# Altium schematic sheet Chip_LORA.SchDoc(Chip_LORA)
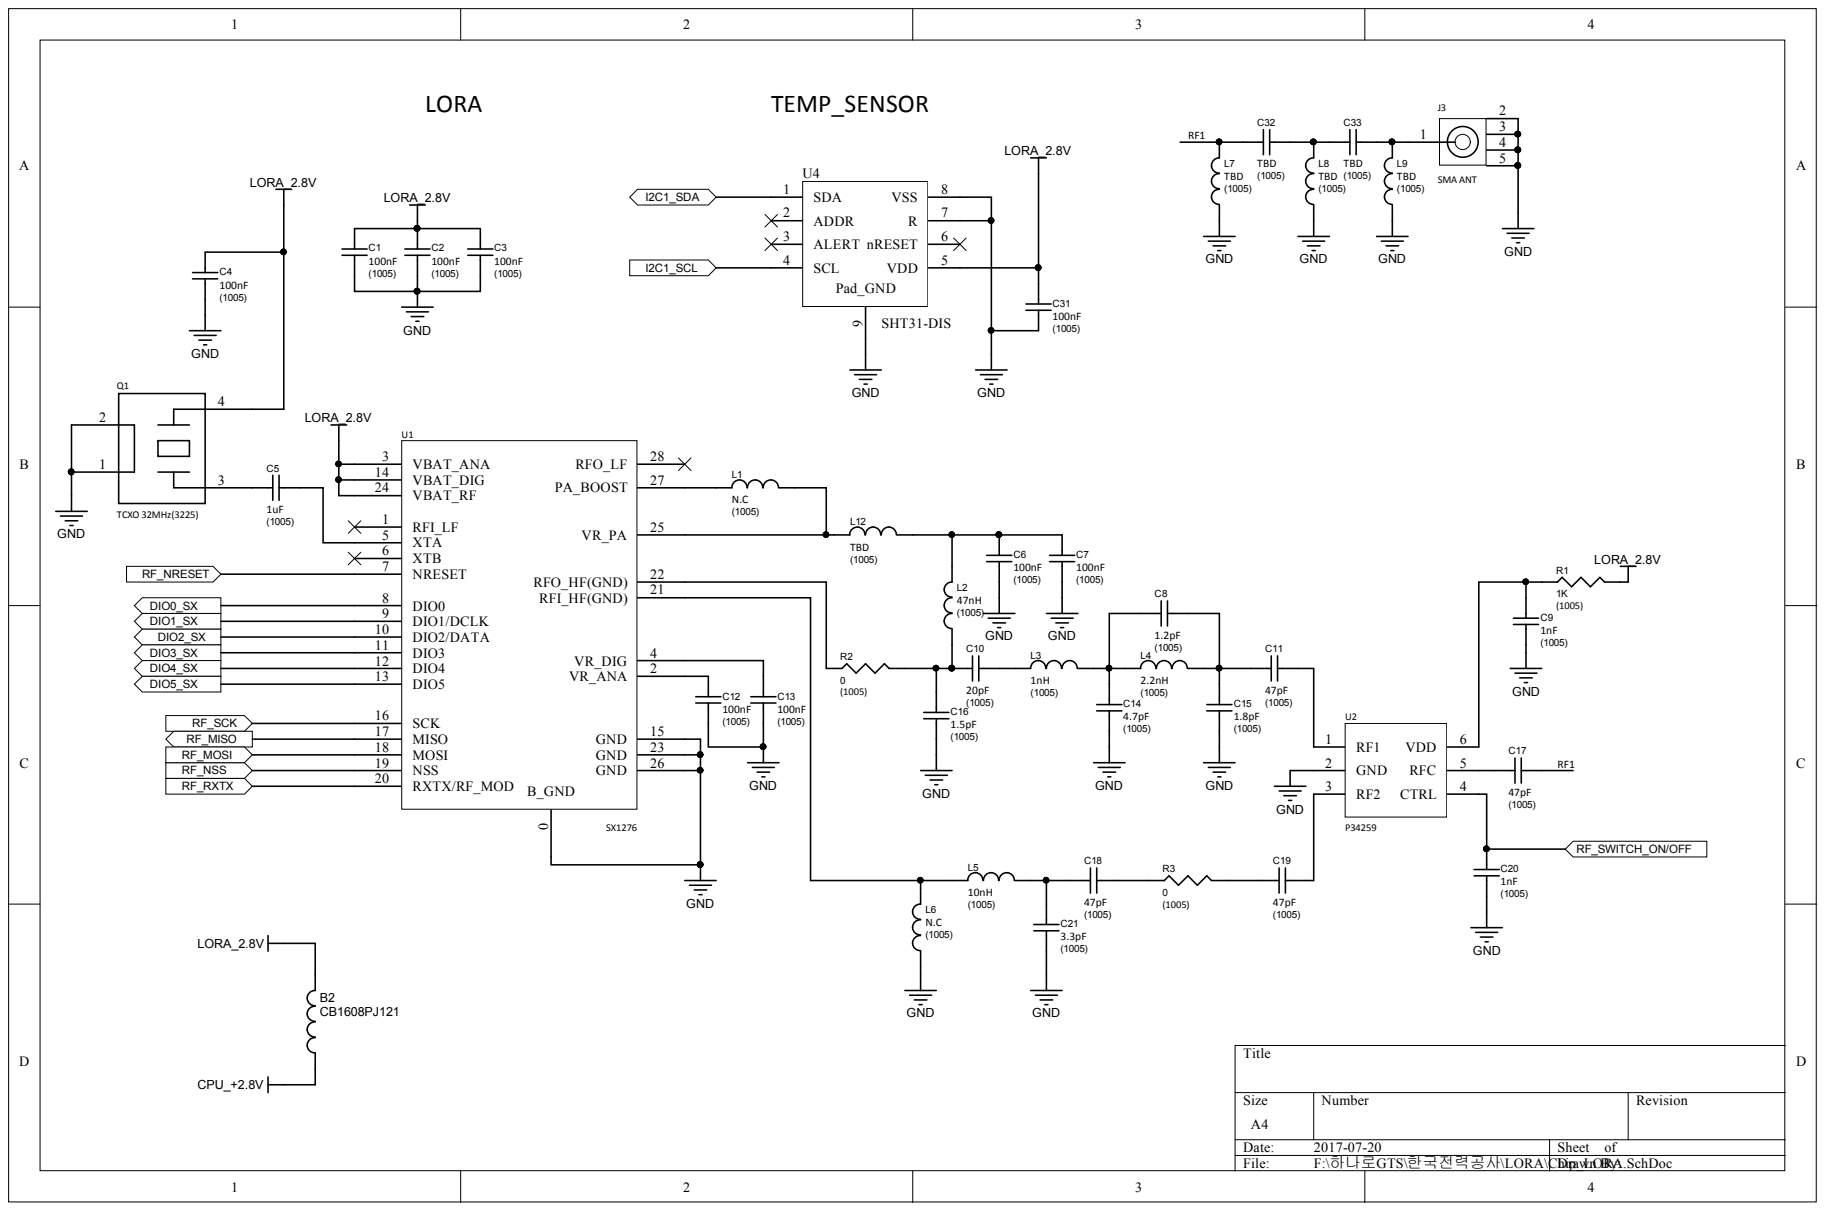
[diagram p0: Chip_LORA.SchDoc]

## Parts
B2  CB1608PJ121  [INDC1608L]
C1  100nF  value (1005)  [C1005]
C2  100nF  value (1005)  [C1005]
C3  100nF  value (1005)  [C1005]
C4  100nF  value (1005)  [C1005]
C5  1uF  value (1005)  [C1005]
C6  100nF  value (1005)  [C1005]
C7  100nF  value (1005)  [C1005]
C8  1.2pF  value (1005)  [C1005]
C9  1nF  value (1005)  [C1005]
C10  20pF  value (1005)  [C1005]
C11  47pF  value (1005)  [C1005]
C12  100nF  value (1005)  [C1005]
C13  100nF  value (1005)  [C1005]
C14  4.7pF  value (1005)  [C1005]
C15  1.8pF  value (1005)  [C1005]
C16  1.5pF  value (1005)  [C1005]
C17  47pF  value (1005)  [C1005]
C18  47pF  value (1005)  [C1005]
C19  47pF  value (1005)  [C1005]
C20  1nF  value (1005)  [C1005]
C21  3.3pF  value (1005)  [C1005]
C31  100nF  value (1005)  [C1005]
C32  TBD  value (1005)  [C1005]
C33  TBD  value (1005)  [C1005]
J3  SMA ANT  [SMA]
L1  N.C  value (1005)  [L1005]
L2  47nH  value (1005)  [L1005]
L3  1nH  value (1005)  [L1005]
L4  2.2nH  value (1005)  [L1005]
L5  10nH  value (1005)  [L1005]
L6  N.C  value (1005)  [L1005]
L7  TBD  value (1005)  [L1005]
L8  TBD  value (1005)  [L1005]
L9  TBD  value (1005)  [L1005]
L12  TBD  value (1005)  [L1005]
Q1  TCXO 32MHz(3225)  [TC323]
R1  1K  value (1005)  [R1005]
R2  0  value (1005)  [R1005]
R3  0  value (1005)  [R1005]
U1  SX1276  [SX1276]
U2  P34259  [PE4259DS]
U4  SHT31-DIS  [SHT31-DIS]

## Ports
DIO0_SX, DIO1_SX, DIO2_SX, DIO3_SX, DIO4_SX, DIO5_SX, I2C1_SCL, I2C1_SDA, RF_MISO, RF_MOSI, RF_NRESET, RF_NSS, RF_RXTX, RF_SCK, RF_SWITCH_ON/OFF

## Nets
CPU_+2.8V: B2.1
GND: C1.1 C2.1 C3.1 C4.1 C6.1 C7.1 C9.2 C12.1 C13.1 C14.2 C15.1 C16.1 C20.2 C21.2 C31.1 J3.2 J3.3 J3.4 J3.5 L6.1 L7.1 L8.1 L9.1 Q1.1 Q1.2 U1.0 U1.15(GND) U1.23(GND) U1.26(GND) U2.2(GND) U4.7(R) U4.8(VSS) U4.9
LORA_2.8V: B2.2 C1.2 C2.2 C3.2 C4.2 C31.2 Q1.4 R1.2 U1.3(VBAT_ANA) U1.14(VBAT_DIG) U1.24(VBAT_RF) U4.5(VDD)
DIO0_SX: U1.8(DIO0) ; port DIO0_SX
DIO1_SX: U1.9(DIO1/DCLK) ; port DIO1_SX
DIO2_SX: U1.10(DIO2/DATA) ; port DIO2_SX
DIO3_SX: U1.11(DIO3) ; port DIO3_SX
DIO4_SX: U1.12(DIO4) ; port DIO4_SX
DIO5_SX: U1.13(DIO5) ; port DIO5_SX
I2C1_SCL: U4.4(SCL) ; port I2C1_SCL
I2C1_SDA: U4.1(SDA) ; port I2C1_SDA
RF1: C17.1 C32.2 L7.2
RF_MISO: U1.17(MISO) ; port RF_MISO
RF_MOSI: U1.18(MOSI) ; port RF_MOSI
RF_NRESET: U1.7(NRESET) ; port RF_NRESET
RF_NSS: U1.19(NSS) ; port RF_NSS
RF_RXTX: U1.20(RXTX/RF_MOD) ; port RF_RXTX
RF_SCK: U1.16(SCK) ; port RF_SCK
RF_SWITCH_ON/OFF: C20.1 U2.4(CTRL) ; port RF_SWITCH_ON/OFF
NetC5_1: C5.1 Q1.3
NetC5_2: C5.2 U1.5(XTA)
NetC6_2: C6.2 C7.2 L2.2 L12.2
NetC8_1: C8.1 C14.1 L3.2 L4.1
NetC8_2: C8.2 C11.2 C15.2 L4.2
NetC9_1: C9.1 R1.1 U2.6(VDD)
NetC10_1: C10.1 L3.1
NetC10_2: C10.2 C16.2 L2.1 R2.2
NetC11_1: C11.1 U2.1(RF1)
NetC12_2: C12.2 U1.2(VR_ANA)
NetC13_2: C13.2 U1.4(VR_DIG)
NetC17_2: C17.2 U2.5(RFC)
NetC18_1: C18.1 R3.1
NetC18_2: C18.2 C21.1 L5.2
NetC19_1: C19.1 U2.3(RF2)
NetC19_2: C19.2 R3.2
NetC32_1: C32.1 C33.2 L8.2
NetC33_1: C33.1 J3.1 L9.2
NetL1_1: L1.1 U1.27(PA_BOOST)
NetL1_2: L1.2 L12.1 U1.25(VR_PA)
NetL5_1: L5.1 L6.2 U1.21(RFI_HF(GND))
NetR2_1: R2.1 U1.22(RFO_HF(GND))

Not connected: U1 1(RFI_LF) 6(XTB) 28(RFO_LF); U4 2(ADDR) 3(ALERT) 6(nRESET)

## Library data of the parts
B2 = BEAD: Code_IEC: 1005; Code_JEITA: 0402; Component Kind: Standard; Description: bead; LatestRevisionDate: 29-May-2009; LatestRevisionNote: IPC-7351 Footprint Added.; Library Name: S_BOARD.SchLib; PackageDescription: Chip Inductor; PackageReference: 0402-A; Published: 8-Jun-2000; Signal Integrity: Inductor; Simulation: INDUCTOR; Value: CB1608PJ121; Publisher: Altium Limited
C1-C7 C9 C11-C17 C19-C21 C31-C33 = C1005: Capacitor (Semiconductor SIM Model); Component Kind: Standard; LatestRevisionDate: 29-May-2009; LatestRevisionNote: IPC-7351 Footprint Added.; PackageDescription: Chip Capacitor; PackageReference: C1206; Published: 8-Jun-2000; Signal Integrity: Cap Semi; Simulation: CAP; Publisher: Altium Limited
  C1-C7 C9 C11-C17 C19-C21: Library Name: S_BOARD.SchLib
  C32 C33: Library Name: LORA.SCHLIB
  C31: Library Name: L_BackBoard.SchLib
C8 C10 C18 = C1005: Capacitor (Semiconductor SIM Model); Value: (1005); Component Kind: Standard; LatestRevisionDate: 29-May-2009; LatestRevisionNote: IPC-7351 Footprint Added.; Library Name: S_BOARD.SchLib; PackageDescription: Chip Capacitor; PackageReference: C1206; Published: 8-Jun-2000; Signal Integrity: Cap Semi; Simulation: CAP; Publisher: Altium Limited
J3 = INT ANT: SMA; Component Kind: Standard; Library Name: LORA.SCHLIB
L1-L9 L12 = L1005: Code_IEC: 1005; Code_JEITA: 0402; Component Kind: Standard; LatestRevisionDate: 29-May-2009; LatestRevisionNote: IPC-7351 Footprint Added.; PackageDescription: Chip Inductor; PackageReference: 0402-A; Published: 8-Jun-2000; Signal Integrity: Inductor; Simulation: INDUCTOR; Publisher: Altium Limited
  L1-L6: Library Name: S_BOARD.SchLib
  L7-L9 L12: Library Name: LORA.SCHLIB
Q1 = TCXO 32MHz: TCXO 32MHz 3.2mmX2.5mm; Component Kind: Standard; Library Name: LORA.SCHLIB
R1 = R1005: Value: (1005); Component Kind: Standard; Library Name: S_BOARD.SchLib
R2 R3 = R1005: Component Kind: Standard; Library Name: S_BOARD.SchLib
U1 = SX1276: 137 MHz to 1020 MHz Low Power Long Range Transceiver; Component Kind: Standard; Library Name: LORA.SCHLIB
U2 = PE4259: SPDT High Power UltraCMOS®  10 MHz–3.0 GHz RF Switch; Component Kind: Standard; Library Name: LORA.SCHLIB
U4 = SHT31-DIS: Humidity and Temperature Sensor; Component Kind: Standard; Library Name: L_BackBoard.SchLib
